# Altium schematic sheet Modules.SchDoc(Modules)
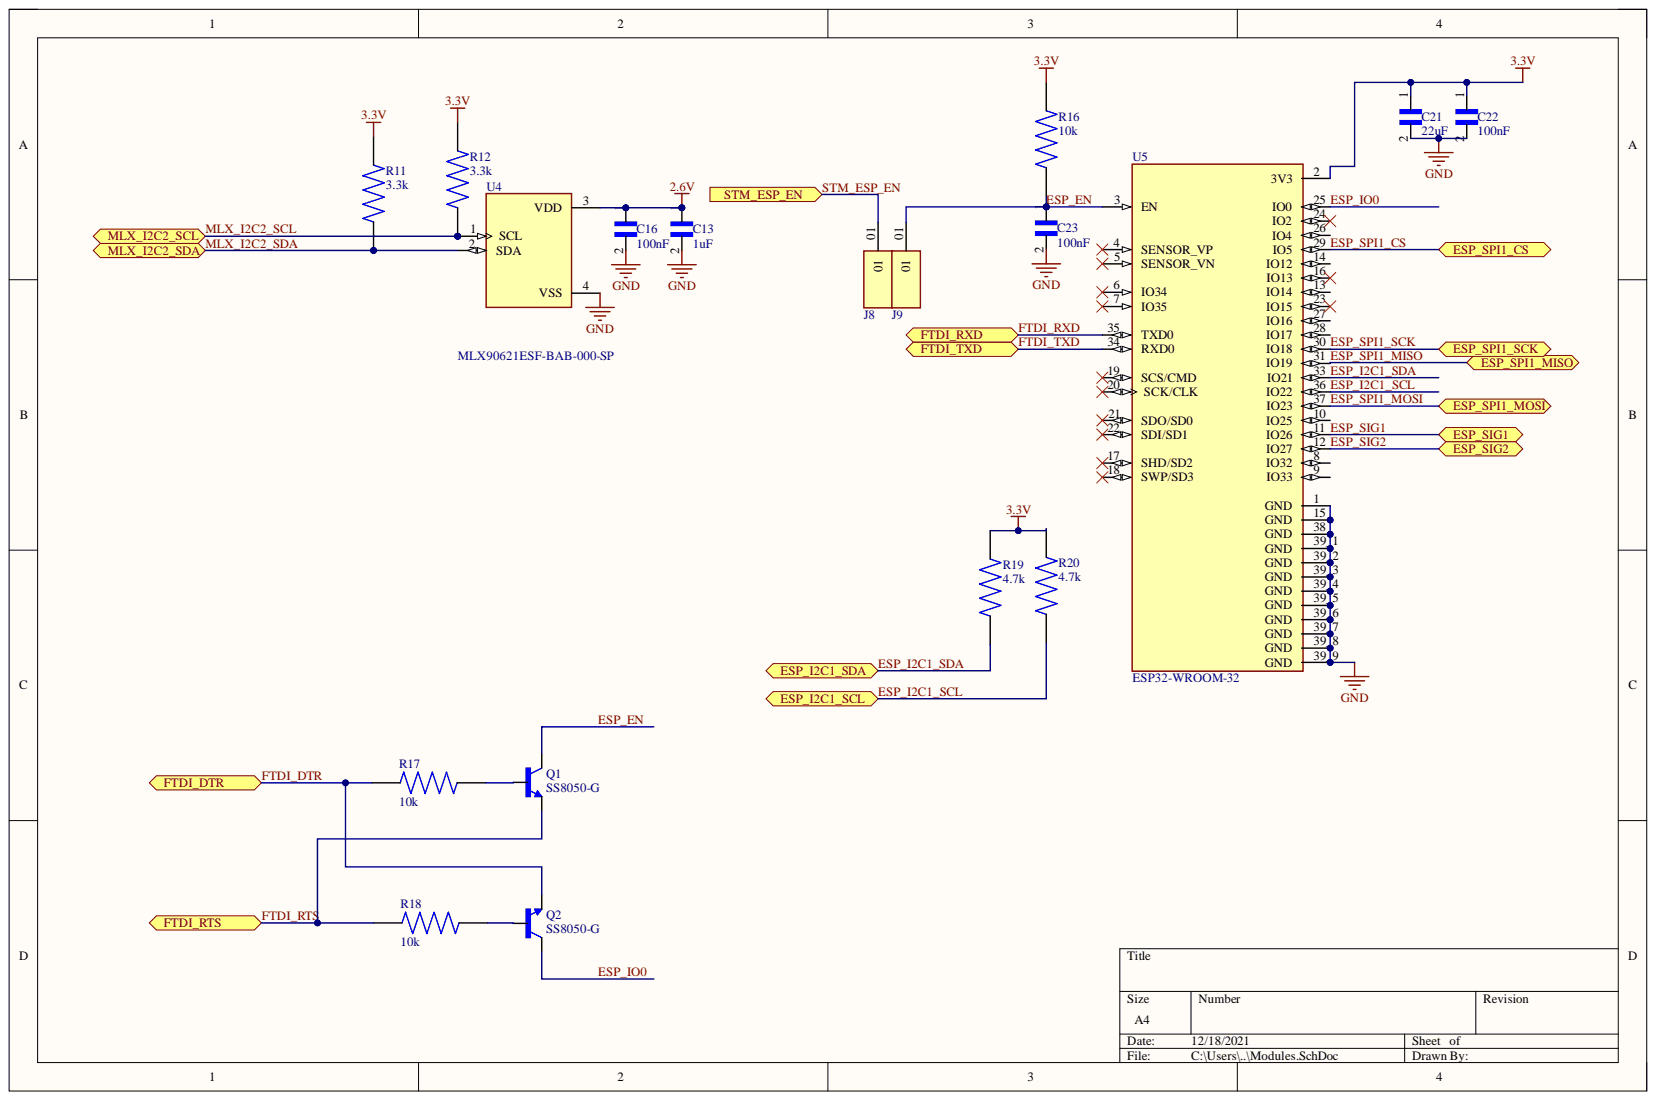
[diagram p0: Modules.SchDoc]

## Parts
C13  1uF  [CAPC1608X90N]
C16  100nF  [CAPC1005X55N]
C21  22uF  [CAPC1608X90N]
C22  100nF  [CAPC1005X55N]
C23  100nF  [CAPC1005X55N]
J8  MTMM-101-XX-X-S-XXX  [SAMTEC_MTMM-101-XX-X-S-XXX]  (value not drawn)
J9  MTMM-101-XX-X-S-XXX  [SAMTEC_MTMM-101-XX-X-S-XXX]  (value not drawn)
Q1  SS8050-G  [SOT23]
Q2  SS8050-G  [SOT23]
R11  3.3k  [RESC1005X35N]
R12  3.3k  [RESC1005X35N]
R16  10k  [RESC1005X35N]
R17  10k  [RESC1005X35N]
R18  10k  [RESC1005X35N]
R19  4.7k  [RESC1005X35N]
R20  4.7k  [RESC1005X35N]
U4  MLX90621ESF-BAB-000-SP  [TO254P940H1340-4]
U5  ESP32-WROOM-32  [MODULE_ESP32-WROOM-32]

## Ports
ESP_I2C1_SCL, ESP_I2C1_SDA, ESP_SIG1, ESP_SIG2, ESP_SPI1_CS, ESP_SPI1_MISO, ESP_SPI1_MOSI, ESP_SPI1_SCK, FTDI_DTR, FTDI_RTS, FTDI_RXD, FTDI_TXD, MLX_I2C2_SCL, MLX_I2C2_SDA, STM_ESP_EN

## Nets
2.6V: C13.1 C16.1 U4.3(VDD)
3.3V: C21.1 C22.1 R11.2 R12.2 R16.2 R19.2 R20.2 U5.2(3V3)
GND: C13.2 C16.2 C21.2 C22.2 C23.2 U4.4(VSS) U5.1(GND) U5.15(GND) U5.38(GND) U5.39_1(GND) U5.39_2(GND) U5.39_3(GND) U5.39_4(GND) U5.39_5(GND) U5.39_6(GND) U5.39_7(GND) U5.39_8(GND) U5.39_9(GND)
ESP_EN: C23.1 J9.01 Q1.3 R16.1 U5.3(EN)
ESP_I2C1_SCL: R20.1 U5.36(IO22) ; port ESP_I2C1_SCL
ESP_I2C1_SDA: R19.1 U5.33(IO21) ; port ESP_I2C1_SDA
ESP_IO0: Q2.3 U5.25(IO0)
ESP_SIG1: U5.11(IO26) ; port ESP_SIG1
ESP_SIG2: U5.12(IO27) ; port ESP_SIG2
ESP_SPI1_CS: U5.29(IO5) ; port ESP_SPI1_CS
ESP_SPI1_MISO: U5.31(IO19) ; port ESP_SPI1_MISO
ESP_SPI1_MOSI: U5.37(IO23) ; port ESP_SPI1_MOSI
ESP_SPI1_SCK: U5.30(IO18) ; port ESP_SPI1_SCK
FTDI_DTR: Q2.2 R17.1 ; port FTDI_DTR
FTDI_RTS: Q1.2 R18.1 ; port FTDI_RTS
FTDI_RXD: U5.35(TXD0) ; port FTDI_RXD
FTDI_TXD: U5.34(RXD0) ; port FTDI_TXD
MLX_I2C2_SCL: R12.1 U4.1(SCL) ; port MLX_I2C2_SCL
MLX_I2C2_SDA: R11.1 U4.2(SDA) ; port MLX_I2C2_SDA
STM_ESP_EN: J8.01 ; port STM_ESP_EN
NetQ1_1: Q1.1 R17.2
NetQ2_1: Q2.1 R18.2

Not connected: U5 4(SENSOR_VP) 5(SENSOR_VN) 6(IO34) 7(IO35) 8(IO32) 9(IO33) 10(IO25) 13(IO14) 14(IO12) 16(IO13) 17(SHD/SD2) 18(SWP/SD3) 19(SCS/CMD) 20(SCK/CLK) 21(SDO/SD0) 22(SDI/SD1) 23(IO15) 24(IO2) 26(IO4) 27(IO16) 28(IO17)

## Library data of the parts
C13 C21 = CL10A475KO8NNNC: 4.7ÂµF Â±10% 16V Ceramic Capacitor X5R 0603 (1608 Metric); MF: Samsung Electro-Mechanics; Arrow_Electronics-Purchase-URL: https://snapeda.com/shop?store=Arrow+Electronics&id=3598183; Availability: Unavailable; Component Kind: Standard; Library Name: CL10A475KO8NNNC.IntLib; MP: CL10A475KO8NNNC; Package: 0603 Samsung; Price: None; SnapEDA_Link: https://www.snapeda.com/parts/CL10A475KO8NNNC/Samsung%20Electro-Mechanics/view-part/3598183/?ref=snap
C16 C22 C23 = CL05B104KO5NNNC: 0.1ÂµF Â±10% 16V Ceramic Capacitor X7R 0402 _1005 Metric_; MF: Samsung Electro-Mechanics; Availability: Unavailable; Component Kind: Standard; Library Name: CL05B104KO5NNNC.IntLib; MP: CL05B104KO5NNNC; Package: 0402 Samsung; Price: None; SnapEDA_Link: https://www.snapeda.com/parts/CL05B104KO5NNNC/Samsung%20Electro-Mechanics/view-part/3598311/?ref=snap
J8 J9 = MTMM-101-XX-X-S-XXX: Connector Header position; MF: Samtec; MP: MTMM-101-04-T-S-115; Availability: Bad; Check_prices: https://www.snapeda.com/parts/MTMM-101-04-T-S-115/Samtec/view-part/3447582/?ref=eda; Component Kind: Standard; Library Name: MTMM-101-XX-X-S-XXX.IntLib; Package: None; Price: None; Purchase-URL: https://pricing.snapeda.com/search/part/MTMM-101-04-T-S-115/?ref=eda; SnapEDA_Link: https://www.snapeda.com/parts/MTMM-101-04-T-S-115/Samtec/view-part/3447582/?ref=snap
Q1 Q2 = SS8050-G: Bipolar (BJT) Transistor NPN 25V 1.5A 100MHz 300mW Surface Mount SOT-23; MF: Comchip Technology; Availability: Warning; Check_prices: https://www.snapeda.com/parts/SS8050-G/Comchip%20Technology/view-part/809142/?ref=eda; Component Kind: Standard; Library Name: SS8050-G.IntLib; MP: SS8050-G; Package: TO-236 Comchip; Price: None; Purchase-URL: https://pricing.snapeda.com/search/part/SS8050-G/?ref=eda; SnapEDA_Link: https://www.snapeda.com/parts/SS8050-G/Comchip%20Technology/view-part/809142/?ref=snap
R11 R12 R16-R20 = RN73C1E1K24BTDF: RN 0402 1K24 0.1% 10PPM 1K RL; Availability: Unavailable; Component Kind: Standard; Library Name: RN73C1E1K24BTDF.IntLib; MP: RN73C1E1K24BTDF; Package: None; Price: None
U4 = MLX90621ESF-BAB-000-SP: IR SENSOR THERMOMETER 2, 6V RoHS; Availability: Unavailable; Component Kind: Standard; Library Name: MLX90621ESF-BAB-000-SP.IntLib; MP: MLX90621ESF-BAB-000-SP; Package: None; Price: None
U5 = ESP32-WROOM-32: Bluetooth, WiFi Transceiver Module 2.4GHz ~ 2.5GHz Surface Mount; MF: Espressif Systems; Component Kind: Standard; Digi-Key_Part_Number: 1904-1010-1-ND; Digi-Key_Purchase_URL: https://www.digikey.com/product-detail/en/espressif-systems/ESP32-WROOM-32/1904-1010-1-ND/8544305?utm_source=snapeda&utm_medium=aggregator&utm_campaign=symbol; Library Name: ESP32-WROOM-32.IntLib; MP: ESP32-WROOM-32; Package: Module Espressif Systems; SnapEDA_Link: https://www.snapeda.com/parts/ESP32-WROOM-32/Espressif%20Systems/view-part/2753322/?ref=snap
